# Altium schematic sheet Digital Pots 1-3 for Daughter Board.SchDoc("Digital Pots 1-3 for Daughter Board")
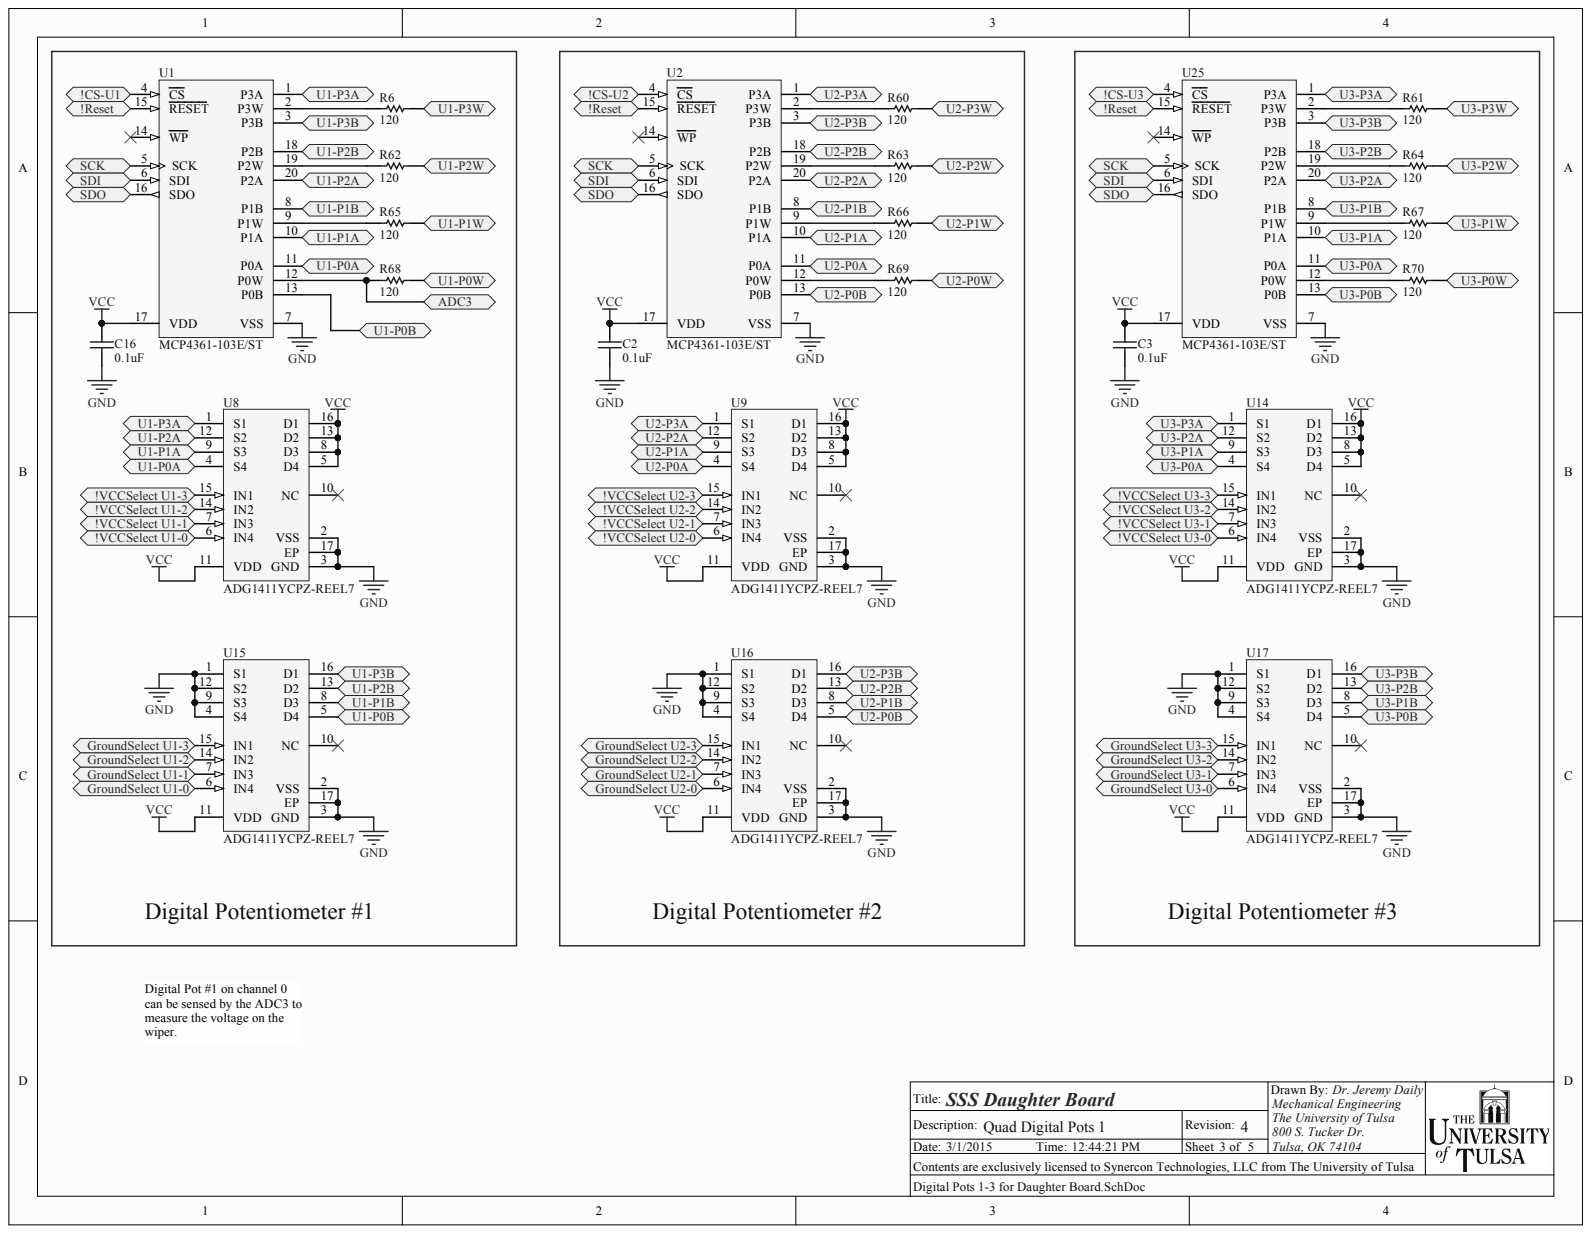
[diagram p0: Digital Pots 1-3 for Daughter Board.SchDoc]

## Parts
C2  0.1uF  [0603]
C3  0.1uF  [0603]
C16  0.1uF  [0603]
R6  120  [0805]
R60  120  [0805]
R61  120  [0805]
R62  120  [0805]
R63  120  [0805]
R64  120  [0805]
R65  120  [0805]
R66  120  [0805]
R67  120  [0805]
R68  120  [0805]
R69  120  [0805]
R70  120  [0805]
U1  MCP4361-103E/ST  [TSSOP-ST20_M]
U2  MCP4361-103E/ST  [TSSOP-ST20_M]
U8  ADG1411YCPZ-REEL7  [ADI-CP-16-13_M]
U9  ADG1411YCPZ-REEL7  [ADI-CP-16-13_M]
U14  ADG1411YCPZ-REEL7  [ADI-CP-16-13_M]
U15  ADG1411YCPZ-REEL7  [ADI-CP-16-13_M]
U16  ADG1411YCPZ-REEL7  [ADI-CP-16-13_M]
U17  ADG1411YCPZ-REEL7  [ADI-CP-16-13_M]
U25  MCP4361-103E/ST  [TSSOP-ST20_M]

## Ports
!CS-U1, !CS-U2, !CS-U3, !RESET, !VCCSELECT U1-0, !VCCSELECT U1-1, !VCCSELECT U1-2, !VCCSELECT U1-3, !VCCSELECT U2-0, !VCCSELECT U2-1, !VCCSELECT U2-2, !VCCSELECT U2-3, !VCCSELECT U3-0, !VCCSELECT U3-1, !VCCSELECT U3-2, !VCCSELECT U3-3, ADC3, GROUNDSELECT U1-0, GROUNDSELECT U1-1, GROUNDSELECT U1-2, GROUNDSELECT U1-3, GROUNDSELECT U2-0, GROUNDSELECT U2-1, GROUNDSELECT U2-2, GROUNDSELECT U2-3, GROUNDSELECT U3-0, GROUNDSELECT U3-1, GROUNDSELECT U3-2, GROUNDSELECT U3-3, SCK, SDI, SDO, U1-P0A, U1-P0B, U1-P0W, U1-P1A, U1-P1B, U1-P1W, U1-P2A, U1-P2B, U1-P2W, U1-P3A, U1-P3B, U1-P3W, U2-P0A, U2-P0B, U2-P0W, U2-P1A, U2-P1B, U2-P1W, U2-P2A, U2-P2B, U2-P2W, U2-P3A, U2-P3B, U2-P3W, U3-P0A, U3-P0B, U3-P0W, U3-P1A, U3-P1B, U3-P1W, U3-P2A, U3-P2B, U3-P2W, U3-P3A, U3-P3B, U3-P3W

## Nets
GND: C2.2 C3.2 C16.2 U1.7(VSS) U2.7(VSS) U8.2(VSS) U8.3(GND) U8.17(EP) U9.2(VSS) U9.3(GND) U9.17(EP) U14.2(VSS) U14.3(GND) U14.17(EP) U15.1(S1) U15.2(VSS) U15.3(GND) U15.4(S4) U15.9(S3) U15.12(S2) U15.17(EP) U16.1(S1) U16.2(VSS) U16.3(GND) U16.4(S4) U16.9(S3) U16.12(S2) U16.17(EP) U17.1(S1) U17.2(VSS) U17.3(GND) U17.4(S4) U17.9(S3) U17.12(S2) U17.17(EP) U25.7(VSS)
VCC: C2.1 C3.1 C16.1 U1.17(VDD) U2.17(VDD) U8.5(D4) U8.8(D3) U8.11(VDD) U8.13(D2) U8.16(D1) U9.5(D4) U9.8(D3) U9.11(VDD) U9.13(D2) U9.16(D1) U14.5(D4) U14.8(D3) U14.11(VDD) U14.13(D2) U14.16(D1) U15.11(VDD) U16.11(VDD) U17.11(VDD) U25.17(VDD)
!CS-U1: U1.4(CS) ; port !CS-U1
!CS-U2: U2.4(CS) ; port !CS-U2
!CS-U3: U25.4(CS) ; port !CS-U3
ADC3: R68.2 U1.12(P0W) ; port ADC3
SCK: U1.5(SCK) U2.5(SCK) U25.5(SCK) ; port SCK
SDI: U1.6(SDI) U2.6(SDI) U25.6(SDI) ; port SDI
SDO: U1.16(SDO) U2.16(SDO) U25.16(SDO) ; port SDO
U1-P0A: U1.11(P0A) U8.4(S4) ; port U1-P0A
U1-P0B: U1.13(P0B) U15.5(D4) ; port U1-P0B
U1-P0W: R68.1 ; port U1-P0W
U1-P1A: U1.10(P1A) U8.9(S3) ; port U1-P1A
U1-P1B: U1.8(P1B) U15.8(D3) ; port U1-P1B
U1-P1W: R65.1 ; port U1-P1W
U1-P2A: U1.20(P2A) U8.12(S2) ; port U1-P2A
U1-P2B: U1.18(P2B) U15.13(D2) ; port U1-P2B
U1-P2W: R62.1 ; port U1-P2W
U1-P3A: U1.1(P3A) U8.1(S1) ; port U1-P3A
U1-P3B: U1.3(P3B) U15.16(D1) ; port U1-P3B
U1-P3W: R6.1 ; port U1-P3W
U2-P0A: U2.11(P0A) U9.4(S4) ; port U2-P0A
U2-P0B: U2.13(P0B) U16.5(D4) ; port U2-P0B
U2-P0W: R69.1 ; port U2-P0W
U2-P1A: U2.10(P1A) U9.9(S3) ; port U2-P1A
U2-P1B: U2.8(P1B) U16.8(D3) ; port U2-P1B
U2-P1W: R66.1 ; port U2-P1W
U2-P2A: U2.20(P2A) U9.12(S2) ; port U2-P2A
U2-P2B: U2.18(P2B) U16.13(D2) ; port U2-P2B
U2-P2W: R63.1 ; port U2-P2W
U2-P3A: U2.1(P3A) U9.1(S1) ; port U2-P3A
U2-P3B: U2.3(P3B) U16.16(D1) ; port U2-P3B
U2-P3W: R60.1 ; port U2-P3W
U3-P0A: U14.4(S4) U25.11(P0A) ; port U3-P0A
U3-P0B: U17.5(D4) U25.13(P0B) ; port U3-P0B
U3-P0W: R70.1 ; port U3-P0W
U3-P1A: U14.9(S3) U25.10(P1A) ; port U3-P1A
U3-P1B: U17.8(D3) U25.8(P1B) ; port U3-P1B
U3-P1W: R67.1 ; port U3-P1W
U3-P2A: U14.12(S2) U25.20(P2A) ; port U3-P2A
U3-P2B: U17.13(D2) U25.18(P2B) ; port U3-P2B
U3-P2W: R64.1 ; port U3-P2W
U3-P3A: U14.1(S1) U25.1(P3A) ; port U3-P3A
U3-P3B: U17.16(D1) U25.3(P3B) ; port U3-P3B
U3-P3W: R61.1 ; port U3-P3W
NetR6_2: R6.2 U1.2(P3W)
NetR60_2: R60.2 U2.2(P3W)
NetR61_2: R61.2 U25.2(P3W)
NetR62_2: R62.2 U1.19(P2W)
NetR63_2: R63.2 U2.19(P2W)
NetR64_2: R64.2 U25.19(P2W)
NetR65_2: R65.2 U1.9(P1W)
NetR66_2: R66.2 U2.9(P1W)
NetR67_2: R67.2 U25.9(P1W)
NetR69_2: R69.2 U2.12(P0W)
NetR70_2: R70.2 U25.12(P0W)
NetU1_15: U1.15(RESET) U2.15(RESET) U25.15(RESET) ; port !RESET
NetU8_6: U8.6(IN4) ; port !VCCSELECT U1-0
NetU8_7: U8.7(IN3) ; port !VCCSELECT U1-1
NetU8_14: U8.14(IN2) ; port !VCCSELECT U1-2
NetU8_15: U8.15(IN1) ; port !VCCSELECT U1-3
NetU9_6: U9.6(IN4) ; port !VCCSELECT U2-0
NetU9_7: U9.7(IN3) ; port !VCCSELECT U2-1
NetU9_14: U9.14(IN2) ; port !VCCSELECT U2-2
NetU9_15: U9.15(IN1) ; port !VCCSELECT U2-3
NetU14_6: U14.6(IN4) ; port !VCCSELECT U3-0
NetU14_7: U14.7(IN3) ; port !VCCSELECT U3-1
NetU14_14: U14.14(IN2) ; port !VCCSELECT U3-2
NetU14_15: U14.15(IN1) ; port !VCCSELECT U3-3
NetU15_6: U15.6(IN4) ; port GROUNDSELECT U1-0
NetU15_7: U15.7(IN3) ; port GROUNDSELECT U1-1
NetU15_14: U15.14(IN2) ; port GROUNDSELECT U1-2
NetU15_15: U15.15(IN1) ; port GROUNDSELECT U1-3
NetU16_6: U16.6(IN4) ; port GROUNDSELECT U2-0
NetU16_7: U16.7(IN3) ; port GROUNDSELECT U2-1
NetU16_14: U16.14(IN2) ; port GROUNDSELECT U2-2
NetU16_15: U16.15(IN1) ; port GROUNDSELECT U2-3
NetU17_6: U17.6(IN4) ; port GROUNDSELECT U3-0
NetU17_7: U17.7(IN3) ; port GROUNDSELECT U3-1
NetU17_14: U17.14(IN2) ; port GROUNDSELECT U3-2
NetU17_15: U17.15(IN1) ; port GROUNDSELECT U3-3

Not connected: U1 14(WP); U2 14(WP); U8 10(NC); U9 10(NC); U14 10(NC); U15 10(NC); U16 10(NC); U17 10(NC); U25 14(WP)

## Library data of the parts
C2 C3 C16 = Cap: Component Kind: Standard; Signal Integrity: Cap; Simulation: CAP; Value: 0.1uF; Library Name: Daily_Integrated_Library.IntLib
R6 R60-R70 = Chip Resistor: Manufacturer: Rohm Semiconductor; PartNumber: ESR10EZPF1200; Component Kind: Standard; Library Name: Daily_Integrated_Library.IntLib
U1 U2 U25 = MCP4361-103E/ST: Manufacturer: Microchip; Component Kind: Standard; PartNumber: MCP4361-103E/ST; Supplier 1: Digi-Key; Supplier Part Number 1: MCP4361-103E/ST-ND; Library Name: Microchip Mixed Signal.IntLib
U8 U9 U14-U17 = ADG1411YCPZ-REEL7: Manufacturer: Analog Devices; Component Kind: Standard; Library Name: Analog Devices Switches and Multiplexers Analog Switches.IntLib; PartNumber: ADG1411YCPZ-REEL7; Supplier 1: Digi-Key; Supplier Part Number 1: ADG1411YCPZ-REEL7TR-ND
